# Altium schematic sheet 3. ADC.SchDoc("3. ADC")
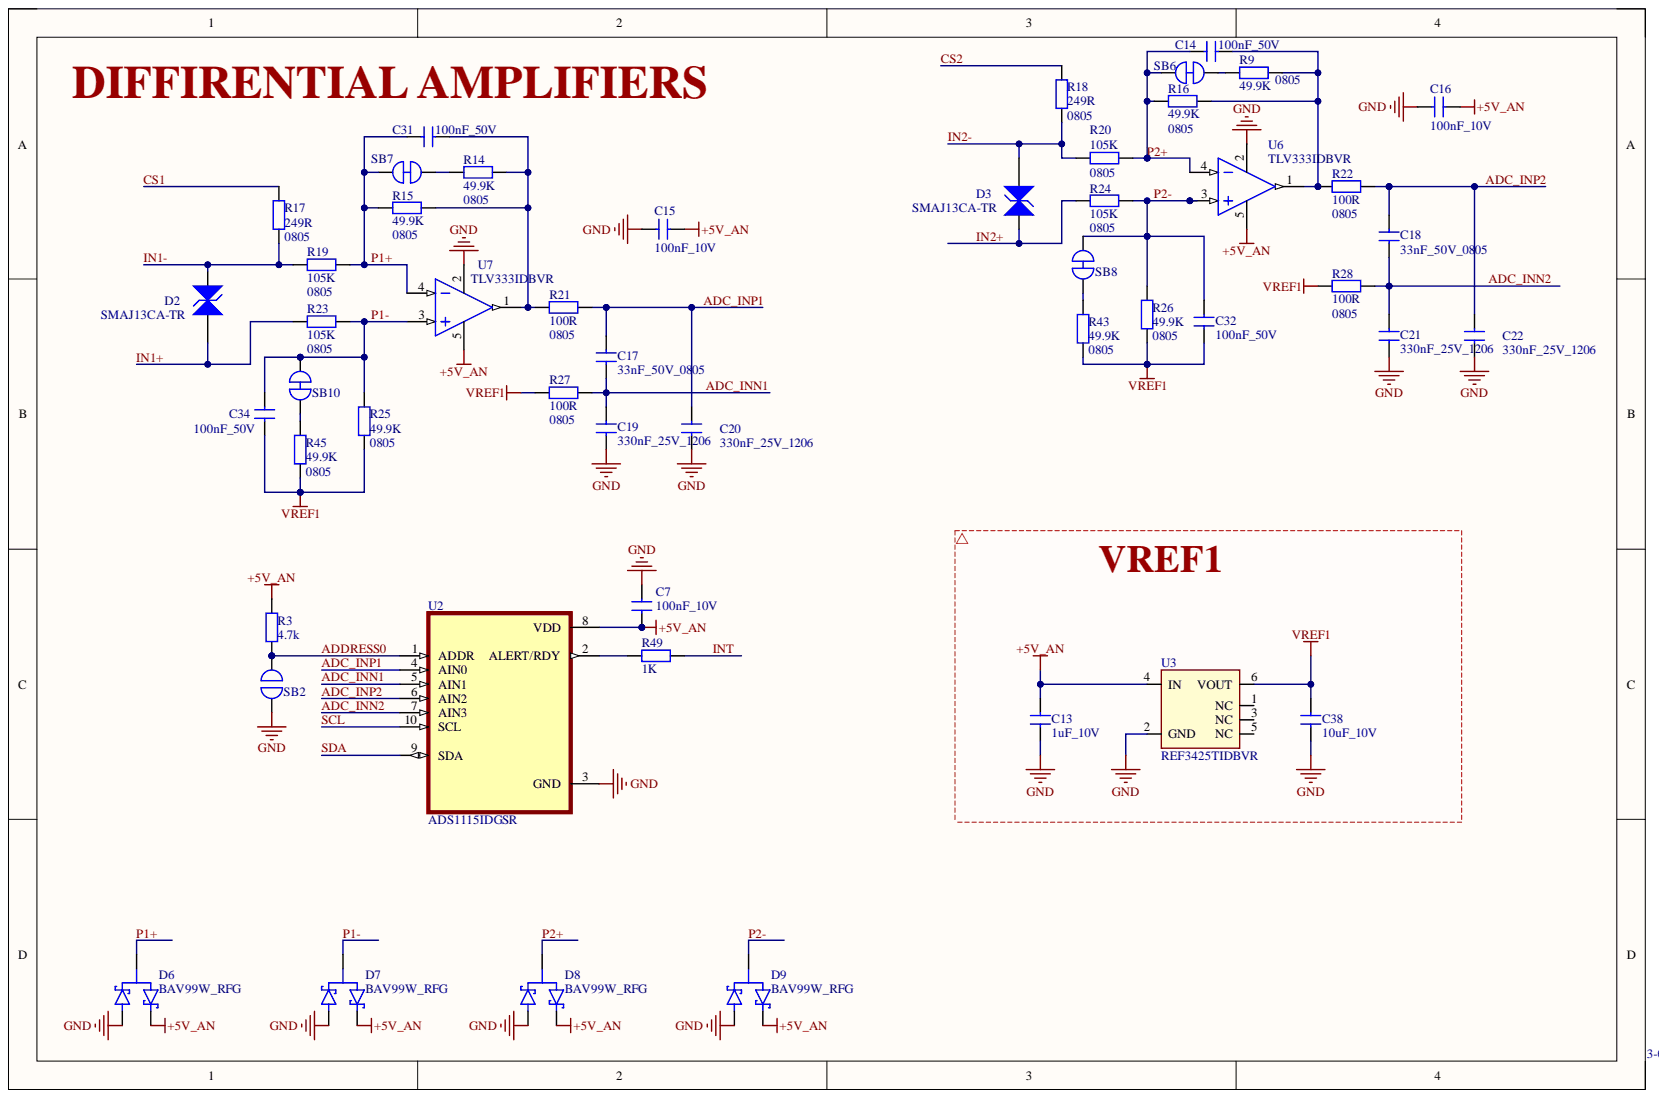
[diagram p0: 3. ADC.SchDoc]

## Parts
C7  100nF_10V  [CN-SMD-0402]
C13  1uF_10V  [C0603]
C14  100nF_50V  [C1206]
C15  100nF_10V  [CN-SMD-0402]
C16  100nF_10V  [CN-SMD-0402]
C17  33nF_50V_0805  [C0805]
C18  33nF_50V_0805  [C0805]
C19  330nF_25V_1206  [C1206]
C20  330nF_25V_1206  [C1206]
C21  330nF_25V_1206  [C1206]
C22  330nF_25V_1206  [C1206]
C31  100nF_50V  [C1206]
C32  100nF_50V  [C1206]
C34  100nF_50V  [C1206]
C38  10uF_10V  [CN-SMD-0402]
D2  SMAJ13CA-TR  [DIODE_DO214AC_SMA]
D3  SMAJ13CA-TR  [DIODE_DO214AC_SMA]
D6  BAV99W_RFG  [DIO_BAV99W RF]
D7  BAV99W_RFG  [DIO_BAV99W RF]
D8  BAV99W_RFG  [DIO_BAV99W RF]
D9  BAV99W_RFG  [DIO_BAV99W RF]
R3  4.7k  [RESC-0603 (1608) - HD]
R9  49.9K  [0805]
R14  49.9K  [0805]
R15  49.9K  [0805]
R16  49.9K  [0805]
R17  249R  [0805]
R18  249R  [0805]
R19  105K  [0805]
R20  105K  [0805]
R21  100R  [0805]
R22  100R  [0805]
R23  105K  [0805]
R24  105K  [0805]
R25  49.9K  [0805]
R26  49.9K  [0805]
R27  100R  [0805]
R28  100R  [0805]
R43  49.9K  [0805]
R45  49.9K  [0805]
R49  1K  [RESC-0603 (1608) - HD]
SB2  SOLDER_JUMPER_NO  [SOLDER_JUMPER_NO]  (value not drawn)
SB6  SOLDER_JUMPER_NC  [SOLDER_JUMPER_NC]  (value not drawn)
SB7  SOLDER_JUMPER_NC  [SOLDER_JUMPER_NC]  (value not drawn)
SB8  SOLDER_JUMPER_NC  [SOLDER_JUMPER_NC]  (value not drawn)
SB10  SOLDER_JUMPER_NC  [SOLDER_JUMPER_NC]  (value not drawn)
U2  ADS1115IDGSR  [VSSOP-10]
U3  REF3425TIDBVR  [SOT23-6]
U6  TLV333IDBVR  [SOT23-5]
U7  TLV333IDBVR  [SOT23-5]

## Nets
+5V_AN: C7.1 C13.2 C15.2 C16.2 D6.2 D7.2 D8.2 D9.2 R3.2 U2.8 U3.4 U6.5 U7.5
GND: C7.2 C13.1 C15.1 C16.1 C19.1 C20.1 C21.1 C22.1 C38.1 D6.1 D7.1 D8.1 D9.1 SB2.1 U2.3 U3.2 U6.2 U7.2
VREF1: C32.1 C34.1 C38.2 R25.1 R26.1 R27.1 R28.1 R43.1 R45.1 U3.6
ADC_INN1: C17.1 C19.2 R27.2 U2.5
ADC_INN2: C18.1 C21.2 R28.2 U2.7
ADC_INP1: C17.2 C20.2 R21.2 U2.4
ADC_INP2: C18.2 C22.2 R22.2 U2.6
ADDRESS0: R3.1 SB2.2 U2.1
CS1: R17.1
CS2: R18.1
IN1+: D2.1 R23.1
IN1-: D2.2 R17.2 R19.1
IN2+: D3.2 R24.1
IN2-: D3.1 R18.2 R20.1
INT: R49.2
P1+: C31.2 D6.3 R15.1 R19.2 SB7.1 U7.4
P1-: C34.2 D7.3 R23.2 R25.2 SB10.2 U7.3
P2+: C14.2 D8.3 R16.1 R20.2 SB6.1 U6.4
P2-: C32.2 D9.3 R24.2 R26.2 SB8.2 U6.3
SCL: U2.10
SDA: U2.9
NetC14_1: C14.1 R9.2 R16.2 R22.1 U6.1
NetC31_1: C31.1 R14.2 R15.2 R21.1 U7.1
NetR9_1: R9.1 SB6.2
NetR14_1: R14.1 SB7.2
NetR43_2: R43.2 SB8.1
NetR45_2: R45.2 SB10.1
NetR49_1: R49.1 U2.2

Not connected: U3 1 3 5

## Library data of the parts
C7 C15 C16 = CL05B104KP5NNNC: 10V 100nF X7R ±10% 0402 Multilayer Ceramic Capacitors MLCC - SMD/SMT ROHS; Component Kind: Standard; LCSC #: C133086; Library Name: Bokra.SCHLIB
C13 = CL05A105KP5NNNC: 10V 1uF X5R ±10% 0603 Multilayer Ceramic Capacitors MLCC - SMD/SMT ROHS; Component Kind: Standard; LCSC #: C14445; Library Name: Bokra.SCHLIB
C14 C31 C32 C34 = GRM31C5C1H104JA01L: 100nF 50V C0G ±5% 1206 Multilayer Ceramic Capacitors MLCC - SMD/SMT ROHS; Component Kind: Standard; LCSC #: C97946; Value: GRM31C5C1H104JA01L; Library Name: Bokra.SCHLIB
C17 C18 = C2012C0G1H333JT000N: 33nF 50V C0G ±5% 0805 Multilayer Ceramic Capacitors MLCC - SMD/SMT ROHS; Component Kind: Standard; LCSC #: C76628; Library Name: Bokra.SCHLIB
C19-C22 = GRM31C5C1E334JE01L: 25V 330nF C0G ±5% 1206 Multilayer Ceramic Capacitors MLCC - SMD/SMT ROHS; Component Kind: Standard; LCSC #: C3837965; Library Name: Bokra.SCHLIB
C38 = CL05A106MP5NUNC: 10V 10uF X5R ±20% 0402 Multilayer Ceramic Capacitors MLCC - SMD/SMT ROHS; Component Kind: Standard; LCSC #: C315248; Library Name: Bokra.SCHLIB
D2 D3 = SMAJ13CA-TR: -55℃~+150℃ 13V 14.4V 18.6A 200nA 27.2V 400W Bidirectional IEC 61000-4-2 TVS SMA(DO-214AC) ESD and Surge Protection (TVS/ESD) ROHS; Component Kind: Standard; LCSC #: C2935145; MPN: SMAJ13CA-TR; Library Name: Bokra.SCHLIB
D6-D9 = BAV99W_RFG: SOT-323, 85V, 0.15A, Switching Diode; MF: Taiwan Semiconductor; MP: BAV99W RF; Value: BAV99W; Component Kind: Standard; LCSC #: C26216; Package: SOT-323-3 Taiwan Semiconductor; SnapEDA_Link: https://www.snapeda.com/parts/BAV99W%20RF/Taiwan+Semiconductor/view-part/?ref=snap; Library Name: Bokra.SCHLIB
R3 = RC0603FR-074K7L: RES 4.7K OHM 1% 1/10W 0603; MFR: Yageo; Category: RESISTOR; Component Kind: Standard; LCSC #: C99782; MPN: RC0603FR-074K7L; Solder: RES-0603; URL: https://www.digikey.com/en/products/detail/yageo/RC0603FR-074K7L/727212; Value: 4.7k; Library Name: Bokra.SCHLIB
R9 R14-R16 R25 R26 R43 R45 = PTFR0805B49K9N9: -55℃~+155℃ 125mW 150V 49.9kΩ Thin Film Resistor ±0.1% ±10ppm/℃ 0805 Chip Resistor - Surface Mount ROHS; Solder: SMT; Component Kind: Standard; LCSC #: C5382601; MPN: PTFR0805B49K9N9; Value: 49.9K; Library Name: Bokra.SCHLIB
R17 R18 = PTFR0805B249RN9: -55℃~+155℃ 130mW 150V 249Ω Thin Film Resistor ±0.1% ±10ppm/℃ 0805 Chip Resistor - Surface Mount ROHS; Solder: SMT; Component Kind: Standard; LCSC #: C5382583; MPN: PTFR0805B249RN9; Value: 249R; Library Name: Bokra.SCHLIB
R19 R20 R23 R24 = PTFR0805B105KN9: -55℃~+125℃ 105kΩ 130mW 150V Thin Film Resistor ±0.1% ±10ppm/℃ 0805 Chip Resistor - Surface Mount ROHS; Solder: SMT; Component Kind: Standard; LCSC #: C2692778; MPN: PTFR0805B105KN9; Value: 105K; Library Name: Bokra.SCHLIB
R21 R22 R27 R28 = PTFR0805B100RN9: -55℃~+125℃ 100Ω 130mW 150V Thin Film Resistor ±0.1% ±10ppm/℃ 0805 Chip Resistor - Surface Mount ROHS; Solder: SMT; Component Kind: Standard; LCSC #: C328435; MPN: PTFR0805B100RN9; Value: 100R; Library Name: Bokra.SCHLIB
R49 = RC0603FR-071KL: RES SMD 1 KOHM 1% 1/10W 0603; Solder: SMT; Component Kind: Standard; LCSC #: C22548; MPN: RC0603FR-071KL; Value: 1K; Library Name: Bokra.SCHLIB
SB2 = SOLDER_JUMPER_NO: Solder Jumper; Component Kind: Net Tie; Library Name: Bokra.SCHLIB
SB6-SB8 SB10 = SOLDER_JUMPER_NC: Solder Jumper; Component Kind: Net Tie; Library Name: Bokra.SCHLIB
U2 = ADS1115IDGSR: -40℃~+125℃ 0.5uA 16 16Hz 1LSB 2 2.048V 2V~5.5V Built-In Built-In I2C Sigma-Delta Support Yes VSSOP-10 Analog to Digital Converters (ADC) ROHS; Component Kind: Standard; LCSC #: C37593; Value: ADS1115IDGSR; Library Name: Bokra.SCHLIB
U3 = REF3425TIDBVR: -40℃~+125℃ 10mA 12V 2.5V 24uVrms 6ppm/℃ 95uA Fixed Series SOT-23-6 Voltage Reference ROHS; Component Kind: Standard; LCSC #: C6643297; Value: REF3425TIDBVR; Library Name: Bokra.SCHLIB
U6 U7 = TLV333IDBVR: -2.75V~-900mV -40℃~+125℃ 0.16V/us 1.0 1.8V~5.5V 115dB 140pA 17uA 20nV/℃ 2uV 30mA 350kHz 5.5V 55nV/√Hz@1kHz 70pA Rail-to-Rail Input, Rail-to-Rail Output SOT-23-5 Precision Op Amps ROHS; Component Kind: Standard; LCSC #: C473369; Value: TLV333IDBVR; Library Name: Bokra.SCHLIB
